# Altium schematic sheet ESP32 perifericos.SchDoc
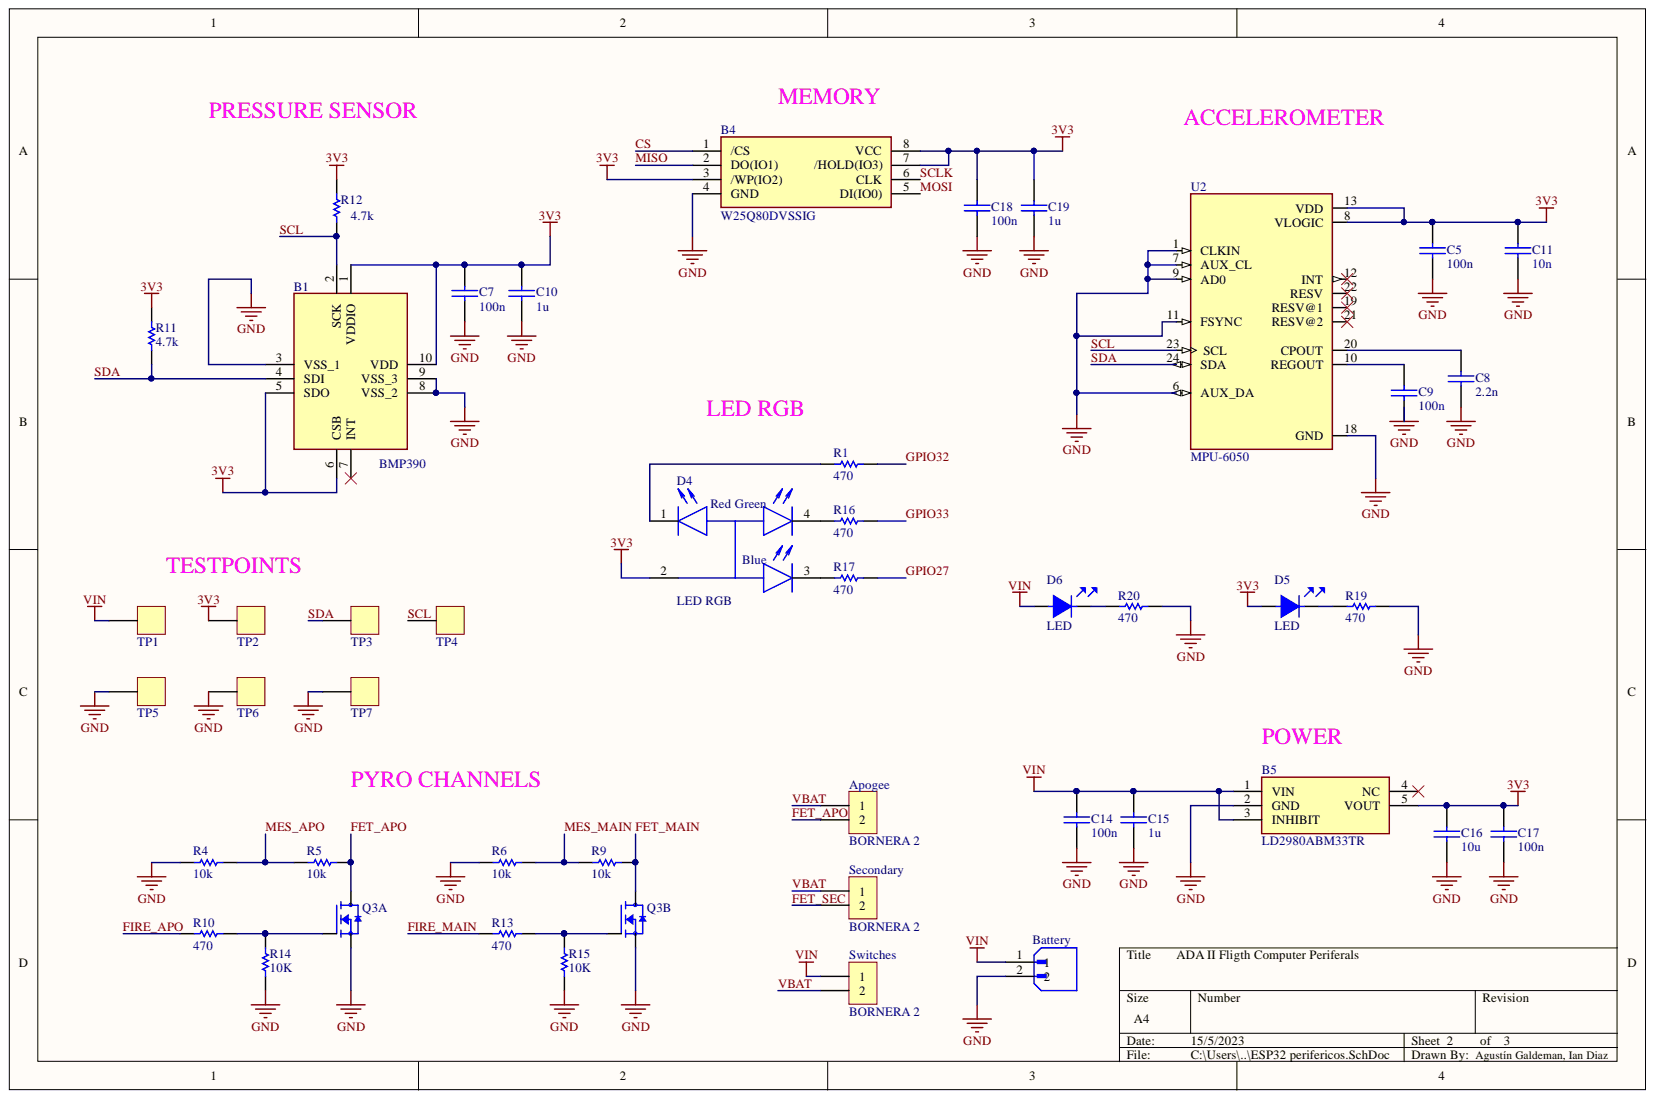
[diagram p0: ESP32 perifericos.SchDoc]

## Parts
Apogee  BORNERA 2  [BORNERA2]
B1  BMP390  [BMP390]
B4  W25Q80DVSSIG  [SOIC127P790X216-8N]
B5  LD2980ABM33TR  [SOT95P280X145-5N]
Battery  BM02B-GHS-TBT(LF)(SN)  [JST_BM02B-GHS-TBT(LF)(SN)]  (value not drawn)
C5  100n  [CAPSMD0805]
C7  100n  [CAPSMD0805]
C8  2.2n  [CAPSMD0805]
C9  100n  [CAPSMD0805]
C10  1u  [CAPSMD0805]
C11  10n  [CAPSMD0805]
C14  100n  [CAPSMD0805]
C15  1u  [CAPSMD0805]
C16  10u  [CAPSMD0805]
C17  100n  [CAPSMD0805]
C18  100n  [CAPSMD0805]
C19  1u  [CAPSMD0805]
D4  LED RGB  [4-PLCC]
D5  LED  [LEDSMD0603]
D6  LED  [LEDSMD0603]
Q3  TSM200N03DPQ33_RGG  [TRANS_TSM200N03DPQ33_RGG]  (value not drawn)  (parts A,B)
R1  470  [RESSMD0805]
R4  10k  [RESSMD0805]
R5  10k  [RESSMD0805]
R6  10k  [RESSMD0805]
R9  10k  [RESSMD0805]
R10  470  [RESSMD0805]
R11  4.7k  [1206]
R12  4.7k  [1206]
R13  470  [RESSMD0805]
R14  10K  [RESSMD0805]
R15  10K  [RESSMD0805]
R16  470  [RESSMD0805]
R17  470  [RESSMD0805]
R19  470  [RESSMD0805]
R20  470  [RESSMD0805]
Secondary  BORNERA 2  [BORNERA2]
Switches  BORNERA 2  [BORNERA2]
TP1  TESTPOINT_5015  [5015]  (value not drawn)
TP2  TESTPOINT_5015  [5015]  (value not drawn)
TP3  TESTPOINT_5015  [5015]  (value not drawn)
TP4  TESTPOINT_5015  [5015]  (value not drawn)
TP5  TESTPOINT_5015  [5015]  (value not drawn)
TP6  TESTPOINT_5015  [5015]  (value not drawn)
TP7  TESTPOINT_5015  [5015]  (value not drawn)
U2  MPU-6050  [QFN24]

## Nets
3V3: B1.1(VDDIO) B1.5(SDO) B1.6(CSB) B1.10(VDD) B4.3(/WP(IO2)) B4.7(/HOLD(IO3)) B4.8(VCC) B5.5(VOUT) C5.1 C7.1 C10.1 C11.1 C16.1 C17.1 C18.1 C19.1 D4.2 D5.A R11.2 R12.2 TP2.1 U2.8(VLOGIC) U2.13(VDD)
GND: B1.3(VSS_1) B1.8(VSS_2) B1.9(VSS_3) B4.4(GND) B5.2(GND) Battery.2 C5.2 C7.2 C8.2 C9.2 C10.2 C11.2 C14.2 C15.2 C16.2 C17.2 C18.2 C19.2 Q3.1 Q3.3 R4.1 R6.1 R14.1 R15.1 R19.2 R20.2 TP5.1 TP6.1 TP7.1 U2.1(CLKIN) U2.6(AUX_DA) U2.7(AUX_CL) U2.9(AD0) U2.11(FSYNC) U2.18(GND)
VIN: B5.1(VIN) B5.3(INHIBIT) Battery.1 C14.1 C15.1 D6.A Switches.1 TP1.1
CS: B4.1(/CS)
FET_APO: Apogee.2 Q3.7_8 R5.2
FET_MAIN: Q3.5_6 R9.2
FET_SEC: Secondary.2
FIRE_APO: R10.1
FIRE_MAIN: R13.1
GPIO27: R17.2
GPIO32: R1.2
GPIO33: R16.2
MES_APO: R4.2 R5.1
MES_MAIN: R6.2 R9.1
MISO: B4.2(DO(IO1))
MOSI: B4.5(DI(IO0))
SCL: B1.2(SCK) R12.1 TP4.1 U2.23(SCL)
SCLK: B4.6(CLK)
SDA: B1.4(SDI) R11.1 TP3.1 U2.24(SDA)
VBAT: Apogee.1 Secondary.1 Switches.2
NetC8_1: C8.1 U2.20(CPOUT)
NetC9_1: C9.1 U2.10(REGOUT)
NetD4_1: D4.1 R1.1
NetD4_3: D4.3 R17.1
NetD4_4: D4.4 R16.1
NetD5_K: D5.K R19.1
NetD6_K: D6.K R20.1
NetQ3_2: Q3.2 R10.2 R14.2
NetQ3_4: Q3.4 R13.2 R15.2

Not connected: B1 7(INT); B5 4(NC); U2 12(INT) 19(RESV@1) 21(RESV@2) 22(RESV)

## Library data of the parts
B1 = BMP390: Board Mount Pressure Sensors; Manufacturer_Name: BOSCH; Geometry.Height: 0.8mm; Component Kind: Standard; Datasheet Link: https://www.bosch-sensortec.com/media/boschsensortec/downloads/datasheets/bst-bmp390-ds002.pdf; Library Name: ESP32 Platform.SchLib; Manufacturer_Part_Number: BMP390; Mouser Part Number: 262-BMP390; Mouser Price/Stock: https://www.mouser.co.uk/ProductDetail/Bosch-Sensortec/BMP390?qs=QNEnbhJQKvYQVfvRMgo2YA%3D%3D
B4 = W25Q80DVSSIG: 8M-bit 3.0V Serial Flash Memory with uniform 4KB sectors and Dual/Quad SPI; Manufacturer_Name: Winbond; Geometry.Height: 2.16mm; Component Kind: Standard; Datasheet Link: https://www.winbond.com/hq/search-resource-file.jsp?partNo=W25Q80DVSSIG&type=datasheet; Library Name: ESP32 Platform.SchLib; Manufacturer_Part_Number: W25Q80DVSSIG; Mouser Part Number: 454-W25Q80DVSSIG; Mouser Price/Stock: https://www.mouser.co.uk/ProductDetail/Winbond/W25Q80DVSSIG?qs=qSfuJ%252Bfl%2Fd6z%2FQYNv5lpMA%3D%3D
B5 = LD2980ABM33TR: LDO Regulator 3.3V 0.05A SOT23-5 LD2980ABM33TR, Low Dropout Voltage Regulator, 0.05A, 3.3 V +/-0.5%, -3  16 Vin, 5-Pin SOT-23; Manufacturer_Name: STMicroelectronics; Geometry.Height: 1.45mm; Component Kind: Standard; Datasheet Link: http://www.st.com/st-web-ui/static/active/en/resource/technical/document/datasheet/CD00001636.pdf; Library Name: ESP32 Platform.SchLib; Manufacturer_Part_Number: LD2980ABM33TR; Mouser Part Number: 511-LD2980ABM33; Mouser Price/Stock: https://www.mouser.co.uk/ProductDetail/STMicroelectronics/LD2980ABM33TR?qs=6luCnr706CzStQ7dZ4O4ww%3D%3D
Battery = BM02B-GHS-TBT(LF)(SN): Connector Header Surface Mount 2 position 0.049 (1.25mm); MF: JST Corporation; Availability: Warning; Check_prices: https://www.snapeda.com/parts/BM02B-GHS-TBT(LF)(SN)/JST/view-part/4833827/?ref=eda; Component Kind: Standard; Library Name: ESP32 Platform.SchLib; MP: BM02B-GHS-TBT(LF)(SN); Package: None; Price: None; SnapEDA_Link: https://www.snapeda.com/parts/BM02B-GHS-TBT(LF)(SN)/JST/view-part/4833827/?ref=snap
C5 C7-C11 C18 C19 = CAP: Capacitor SMD 4.7nF; Component Kind: Standard; Library Name: ESP32 Platform.SchLib
C14-C17 = CAP: Capacitor SMD; Component Kind: Standard; Library Name: ESP32 Platform.SchLib
D4 = LED RGB: Red, Green, Blue _RGB_ 622nm Red, 530nm Green, 470nm Blue LED Indication - Discrete 1.9V Red, 3V Green, 3V Blue 4-PLCC; MF: Cree Inc.; MP: CLVBA-FKA-CAEDH8BBB7A363; Component Kind: Standard; Digi-Key_Part_Number: CLVBA-FKA-CAEDH8BBB7A363CT-ND; Digi-Key_Purchase_URL: https://www.digikey.com/product-detail/en/cree-inc/CLVBA-FKA-CAEDH8BBB7A363/CLVBA-FKA-CAEDH8BBB7A363CT-ND/2650500?utm_source=snapeda&utm_medium=aggregator&utm_campaign=symbol; Library Name: ESP32 Platform.SchLib; Package: PLCC-4 Cree; SnapEDA_Link: https://www.snapeda.com/parts/CLVBA-FKA-CAEDH8BBB7A363/Cree%20Inc./view-part/134493/?ref=snap
D5 D6 = LED: LED SMD Verde; Component Kind: Standard; Library Name: ESP32 Platform.SchLib
Q3 = TSM200N03DPQ33_RGG: MF: Taiwan Semiconductor; Availability: Unavailable; Component Kind: Standard; Library Name: ESP32 Platform.SchLib; MP: TSM200N03DPQ33_RGG; Package: None; Price: None
R1 R4-R6 R9-R17 R19 R20 = RES: Resistencia SMD 470ohms; Component Kind: Standard; Library Name: ESP32 Platform.SchLib
TP1-TP7 = TESTPOINT_5015: Thimble/Copper Rod/Test Ring ROHS; Manufacturer: Ronghe; Manufacturer Part Number: RH-5015; Component Kind: Standard; Library Name: ESP32 Platform.SchLib; Supplier: JLCPCB; Supplier Part Number: C5199798
U2 = MPU-6050: MPU-6050 series Accelerometer, Gyroscope, 3 Axis Sensor Evaluation Board; MF: TDK InvenSense; Availability: In Stock; Check_prices: https://www.snapeda.com/parts/MPU-6050/TDK+InvenSense/view-part/?ref=eda; Component Kind: Standard; Library Name: ESP32 Platform.SchLib; MP: MPU-6050; Package: QFN-24 InvenSense; Price: None; Purchase-URL: https://pricing.snapeda.com/search/part/MPU-6050/?ref=eda; SnapEDA_Link: https://www.snapeda.com/parts/MPU-6050/TDK+InvenSense/view-part/?ref=snap
Apogee Secondary Switches = BORNERA 2: Component Kind: Standard; Library Name: ESP32 Platform.SchLib
